# Altium schematic sheet Audio_ADC.SchDoc(Audio_ADC)
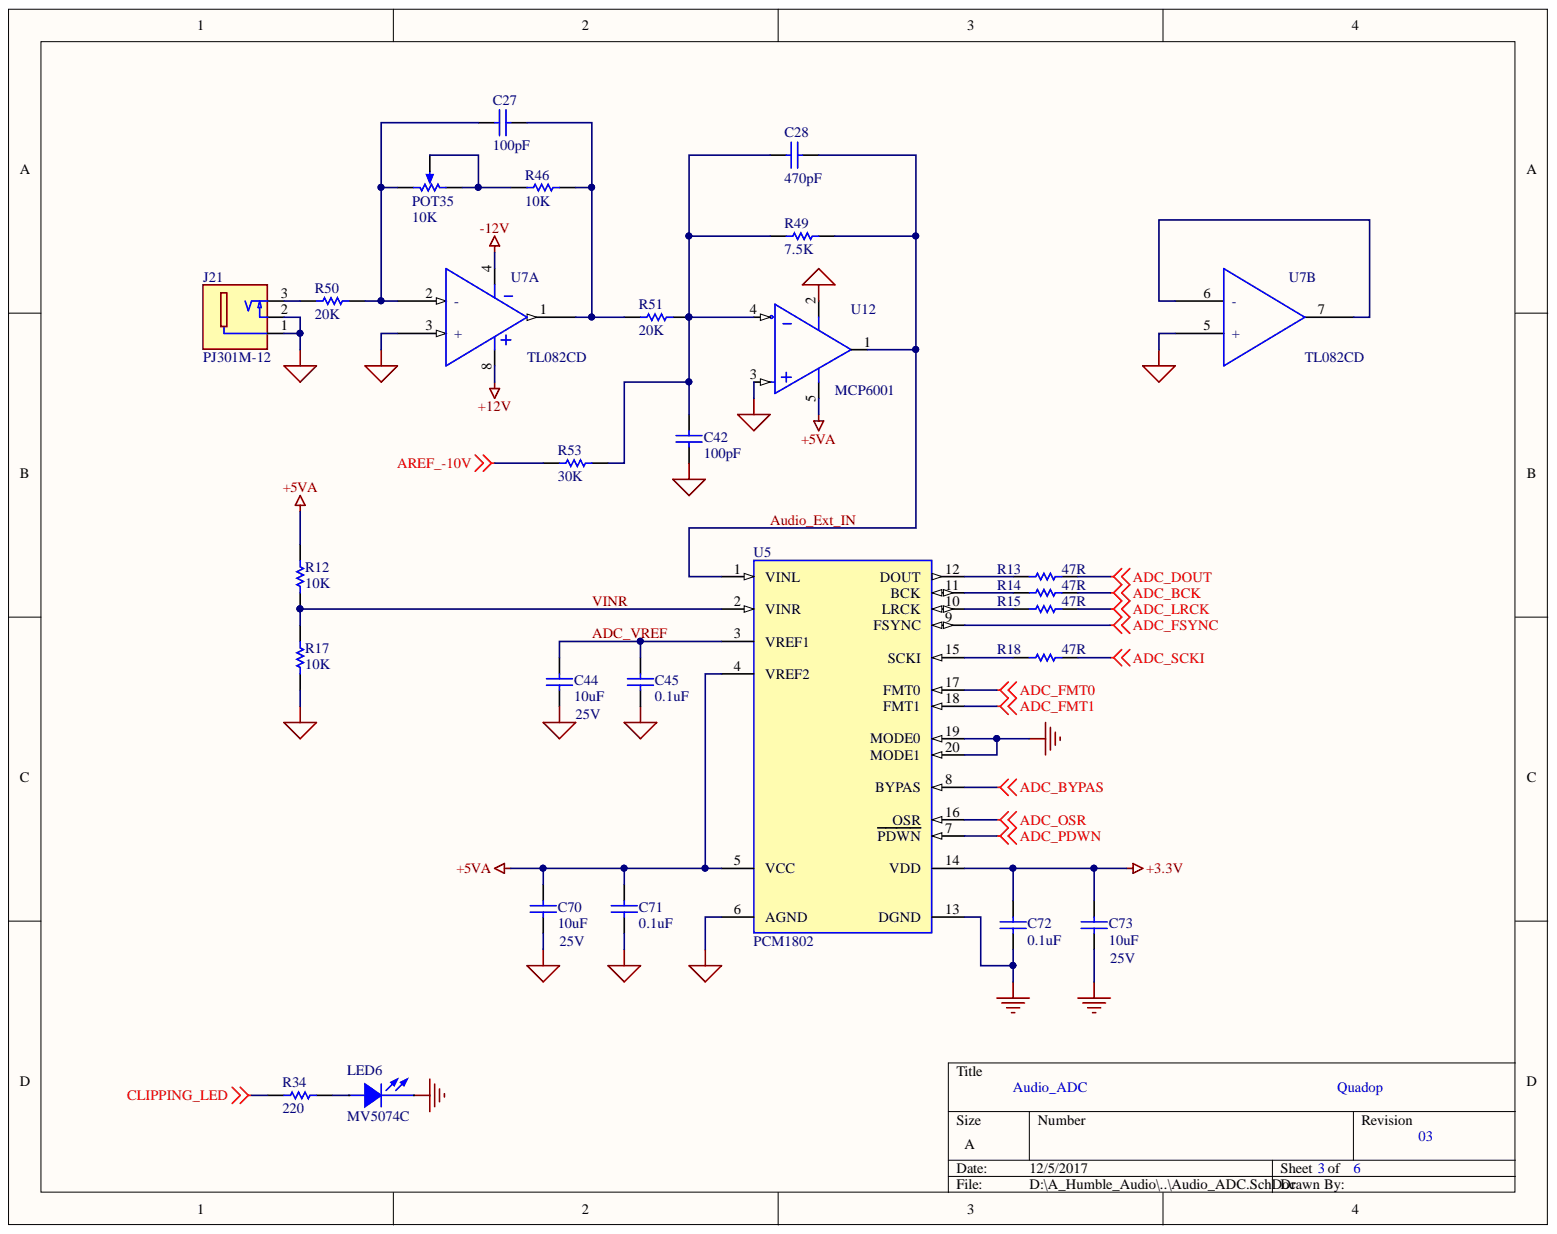
[diagram p0: Audio_ADC.SchDoc]

## Parts
C27  100pF  [C0603]
C28  470pF  [C0603]
C42  100pF  [C0603]
C44  10uF  Voltage: 25V  [C0805]
C45  0.1uF  [C0603]
C70  10uF  Voltage: 25V  [C0805]
C71  0.1uF  [C0603]
C72  0.1uF  [C0603]
C73  10uF  Voltage: 25V  [C0805]
J21  PJ301M-12  [EP_J_3.5_PJ301M-12]
LED6  MV5074C  [VDLED2_5XF3.2]
POT35  10K  [POT_R0904N]
R12  10K  [R0603]
R13  47R  [R0603]
R14  47R  [R0603]
R15  47R  [R0603]
R17  10K  [R0603]
R18  47R  [R0603]
R34  220  [R0603]
R46  10K  [R0603]
R49  7.5K  [R0603]
R50  20K  [R0603]
R51  20K  [R0603]
R53  30K  [R0603]
U5  PCM1802  [SSOP20_0.65/6X7.5]
U7  TL082CD  [SO8]  (parts A,B)
U12  MCP6001  [SOT23_5]

## Nets
+3.3V: C72.1 C73.1 U5.14(VDD)
+5VA: C70.1 C71.1 R12.1 U5.4(VREF2) U5.5(VCC) U12.5
+12V: U7.8
-12V: U7.4
ADC_BCK: R14.2
ADC_BYPAS: U5.8(BYPAS)
ADC_DOUT: R13.2
ADC_FMT0: U5.17(FMT0)
ADC_FMT1: U5.18(FMT1)
ADC_FSYNC: U5.9(FSYNC)
ADC_LRCK: R15.2
ADC_OSR: U5.16(OSR)
ADC_PDWN: U5.7(PDWN)
ADC_SCKI: R18.2
AGND: C42.1 C44.2 C45.2 C70.2 C71.2 J21.1 J21.2 R17.2 U5.6(AGND) U7.3(+) U7.5(+) U12.2 U12.3
AREF_-10V: R53.1
CLIPPING_LED: R34.1
GND: C72.2 C73.2 LED6.2 U5.13(DGND) U5.19(MODE0) U5.20(MODE1)
ADC_VREF: C44.1 C45.1 U5.3(VREF1)
Audio_Ext_IN: C28.2 R49.2 U5.1(VINL) U12.1
VINR: R12.2 R17.1 U5.2(VINR)
NetC27_1: C27.1 POT35.1 R50.2 U7.2(-)
NetC27_2: C27.2 R46.2 R51.1 U7.1
NetC28_1: C28.1 C42.2 R49.1 R51.2 R53.2 U12.4
NetJ21_3: J21.3 R50.1
NetLED6_1: LED6.1 R34.2
NetPOT35_2: POT35.2 POT35.3 R46.1
NetR13_1: R13.1 U5.12(DOUT)
NetR14_1: R14.1 U5.11(BCK)
NetR15_1: R15.1 U5.10(LRCK)
NetR18_1: R18.1 U5.15(SCKI)
NetU7_6: U7.6(-) U7.7

## Library data of the parts
C27 C42 = 00000112: 100pF 50V 5% NP0 0603; PSpice: C; Lead time: Stock; Database Table Name: Capacitor; Voltage: 50V; Value: 100pF; Tolerance: 5%; Tape Width: 8mm; SMD: Yes; Price: 0.015; Part Type: Ceramic; Part Number: 00000112; MOQ: 4000; Main distributor: Rutronik; Component Kind: Standard; Availability: In Stock; Library Name: Gedo_DB.DbLib
C28 = 00005456: 470pF 50V +-10% X7R 0603 -55+125; Manufacturer Part Number: MCCA000216; Manufacturer: MULTICOMP; PSpice: C; Database Table Name: Capacitor; Voltage: 50V; Value: 470pF; Tolerance: +-10%; Tape Width: 8mm; SMD: Yes; Price: 0.01; Part Type: Ceramic; Part Number: 00005456; Distributor Part Number: 1759079; Distributor: Farnell; Component Kind: Standard; Availability: In Stock; Library Name: Gedo_DB.DbLib
C44 C70 C73 = 00106253: 10uF 25V 10% X5R 0805 -55+85; Manufacturer Part Number: TMK212BBJ106KG-T; Manufacturer: Taiyo Yuden; Tolerance: +-10%; PSpice: C; Database Table Name: Capacitor; Value: 10uF; Tape Width: 8mm; SMD: Yes; Price: 0.385; Part Type: Ceramic; Part Number: 00106253; Package: 3000T&R; Distributor Part Number: 587-2985-1-ND; Distributor: Digi-Key; Component Kind: Standard; Library Name: Gedo_DB.DbLib
C45 C71 C72 = 00000538: 100nF 50V +-10% X7R 0603 -55+125; Manufacturer Part Number: GRM188R71H104KA93J; Manufacturer: MURATA; PSpice: C; Lead time: Stock; Database Table Name: Capacitor; Voltage: 50V; Value: 0.1uF; Tolerance: +-10%; Tape Width: 8mm; SMD: Yes; Price: 0.0048; Part Type: Ceramic; Part Number: 00000538; Package: 10000T&R330mm; MOQ: 4000; Main distributor: Rutronik; Distributor: Rutronik; Component Kind: Standard; Availability: In Stock; ActivepartsID: http://search.murata.co.jp/Ceramy/GrsearchViewAction.do;jsessionid=C4D720578E4D2E51EC807AFF78C1B5C3; Library Name: Gedo_DB.DbLib
J21 = 72502011: 3pin EARPHONE JACK PANEL MOUNT VERTICAL F3.5; 0.5A 30V; Manufacturer: Thonkiconn; Database Table Name: Connectors; Value: PJ301M-12; Price: 0.48; Part Type: EarPhone jack F3.5; Part Number: 72502011; Manufacturer Part Number: PJ301M-12; Distributor: THONKICONN; Component Kind: Standard; Library Name: Gedo_DB.DbLib
LED6 = 32010221: Red Diff LED 3mm 565nm 2V@10mA 9mcd T-1; Manufacturer: Everlight Electronics Co Ltd; PSpice: D; Database Table Name: Diodai; Value: MV5074C; Price: 0.166; Part Type: LED; Part Number: 32010221; Manufacturer Part Number: MV5074C; Distributor Part Number: 1080-1101-ND; Distributor: Digi-Key; Component Kind: Standard; Library Name: Gedo_DB.DbLib
POT35 = 18005010: Potentiometer 10K, 9mm, mono,linear,9.7x12.5x25mm; Manufacturer Part Number: R0904N-B10K,L-25KQ; Manufacturer: Song Huei; Tolerance: 20%; PSpice: R; Power: 0.05W; Database Table Name: Resistor; Value: 10K; Price: 0.85; Part type: Trimmer; Part Number: 18005010; Distributor Part Number: R0904N-B10K,L-25KQ; Distributor: thonk.co.uk; Component Kind: Standard; Library Name: Gedo_DB.DbLib
R12 R17 R46 = 11010022: 10K 1% 0603 1/10W 100ppm; Manufacturer Part Number: RC0603FR-0710KL; Manufacturer: YAGEO; PSpice: R; Database Table Name: Resistor; Value: 10K; Tolerance: 1%; Tape Width: 8mm; SMD: Yes; Price: 0.0057; Power: 1/10W; Part type: SMD 1%; Part Number: 11010022; Package: 5000T&R; Distributor Part Number: 311-10.0KHRCT-ND; Distributor: Digi-Key; Component Kind: Standard; Availability: In Stock; Library Name: Gedo_DB.DbLib
R13-R15 R18 = 11047092: 47R 1% 0603 1/10W 100ppm; Manufacturer Part Number: RC0603FR-0747RL; Manufacturer: YAGEO; PSpice: R; Database Table Name: Resistor; Value: 47R; Tolerance: 1%; Tape Width: 8mm; SMD: Yes; Price: 0.013; Power: 1/10W; Part type: SMD 1%; Part Number: 11047092; Package: 5000T&R; Distributor Part Number: 311-47.0HRTR-ND; Distributor: Digi-Key; Component Kind: Standard; Availability: In Stock; Library Name: Gedo_DB.DbLib
R34 = 11022002: 220R 1% 0603 1/10W 100ppm; Manufacturer Part Number: RC0603FR-07220RL; Manufacturer: YAGEO; PSpice: R; Database Table Name: Resistor; Value: 220; Tolerance: 1%; Tape Width: 8mm; SMD: Yes; Price: 0.01; Power: 1/10W; Part type: SMD 1%; Part Number: 11022002; Package: 5000T&R; Distributor Part Number: 311-220HRCT-ND; Distributor: Digi-Key; Component Kind: Standard; Availability: In Stock; Library Name: Gedo_DB.DbLib
R49 = 11075012: 7K5 1% 0603 1/10W 100ppm; Manufacturer Part Number: RC0603FR-077K5L; Manufacturer: YAGEO; PSpice: R; Database Table Name: Resistor; Value: 7.5K; Tolerance: 1%; Tape Width: 8mm; SMD: Yes; Price: 0.013; Power: 1/10W; Part type: SMD 1%; Part Number: 11075012; Package: 5000T&R; Distributor Part Number: 311-7.50KHRTR-ND; Distributor: Digi-Key; Component Kind: Standard; Availability: In Stock; ActivepartsID: http://www.yageo.com/pdf/Pu-RC0603_51_PbFree_L_2.pdf; Library Name: Gedo_DB.DbLib
R50 R51 = 11020022: 20K 1% 0603 1/10W 100ppm; Manufacturer Part Number: RC0603FR-20KL; Manufacturer: YAGEO; PSpice: R; Database Table Name: Resistor; Value: 20K; Tolerance: 1%; Tape Width: 8mm; SMD: Yes; Price: 0.013; Power: 1/10W; Part type: SMD 1%; Part Number: 11020022; Component Kind: Standard; Availability: In Stock; Library Name: Gedo_DB.DbLib
R53 = 11030022: 30K 1% 0603 1/10W 100ppm; Manufacturer Part Number: RC0603FR-0730KL; Manufacturer: YAGEO; PSpice: R; Lead time: Stock; Database Table Name: Resistor; Value: 30K; Tolerance: 1%; Tape Width: 8mm; SMD: Yes; Price: 0.013; Power: 1/10W; Part type: SMD 1%; Part Number: 11030022; Package: 5000T&R; MOQ: 5000; Distributor Part Number: 311-30.0KHRCT-ND; Distributor: Digi-Key; Component Kind: Standard; Availability: In Stock; Library Name: Gedo_DB.DbLib
U5 = 50621802: Delta Sigma Audio ADC, 24-bit, 96kHz, 2-ch, 2.7-3.6V, 4.5-5.5V, SSOP20,-40+85; Manufacturer Part Number: PCM1802DB; Manufacturer: Texas Instruments; Database Table Name: IC; Value: PCM1802; Tape Width: 16mm; SMD: Yes; Price: 4.24; Part Type: Audio ADC; Part Number: 50621802; Package: 2000T&R; Distributor Part Number: PCM1802DB-ND; Distributor: Digi-Key; Component Kind: Standard; Library Name: Gedo_DB.DbLib
U7 = 51200382: Low Power Dual Amplifier,JFET input,Vsp=7-36V,3MHz,13V/us,SO8,0+70C; Manufacturer Part Number: TL082SG-13; Manufacturer: DIODES INC.; Database Table Name: IC; Value: TL082CD; SMD: Yes; Part Type: OP Amplifiers; Part Number: 51200382; Distributor Part Number: 1904044; Distributor: Farnell; Component Kind: Standard; Library Name: Gedo_DB.DbLib
U12 = 51260012: Op amplifier,Rail-to-rail,Vs=1.8-6.0V,1MHz,Vo=0.6V/us,SOT23-5,-40+85; Manufacturer Part Number: MCP6001T-I/OT; Manufacturer: Microchip Technology; Database Table Name: IC; Value: MCP6001; SMD: Yes; Price: 0.2; Part Type: OP Amplifiers; Part Number: 51260012; Package: 3000T&R; Distributor Part Number: MCP6001T-I/OTCT-ND; Distributor: Digi-Key; Component Kind: Standard; Library Name: Gedo_DB.DbLib
